# Altium schematic sheet Schematic.SchDoc(Schematic)
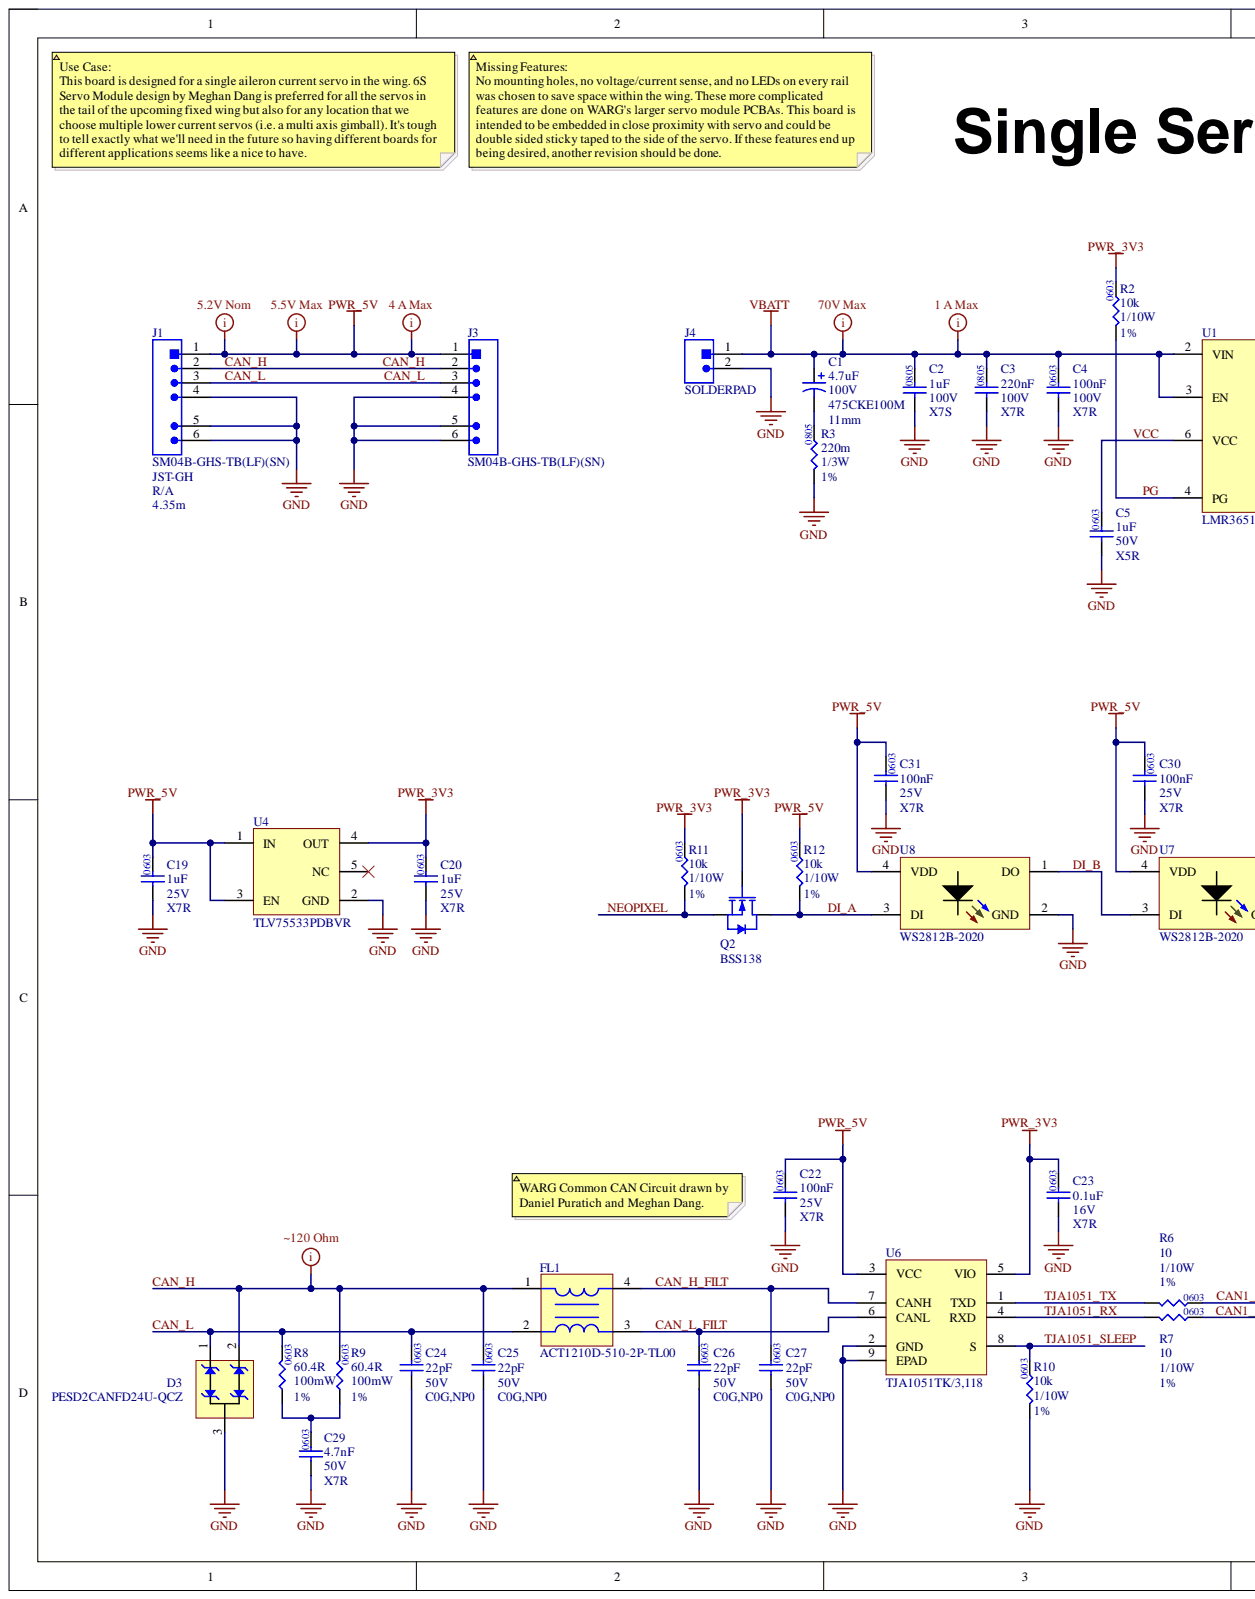
[diagram p0: Schematic.SchDoc, left]
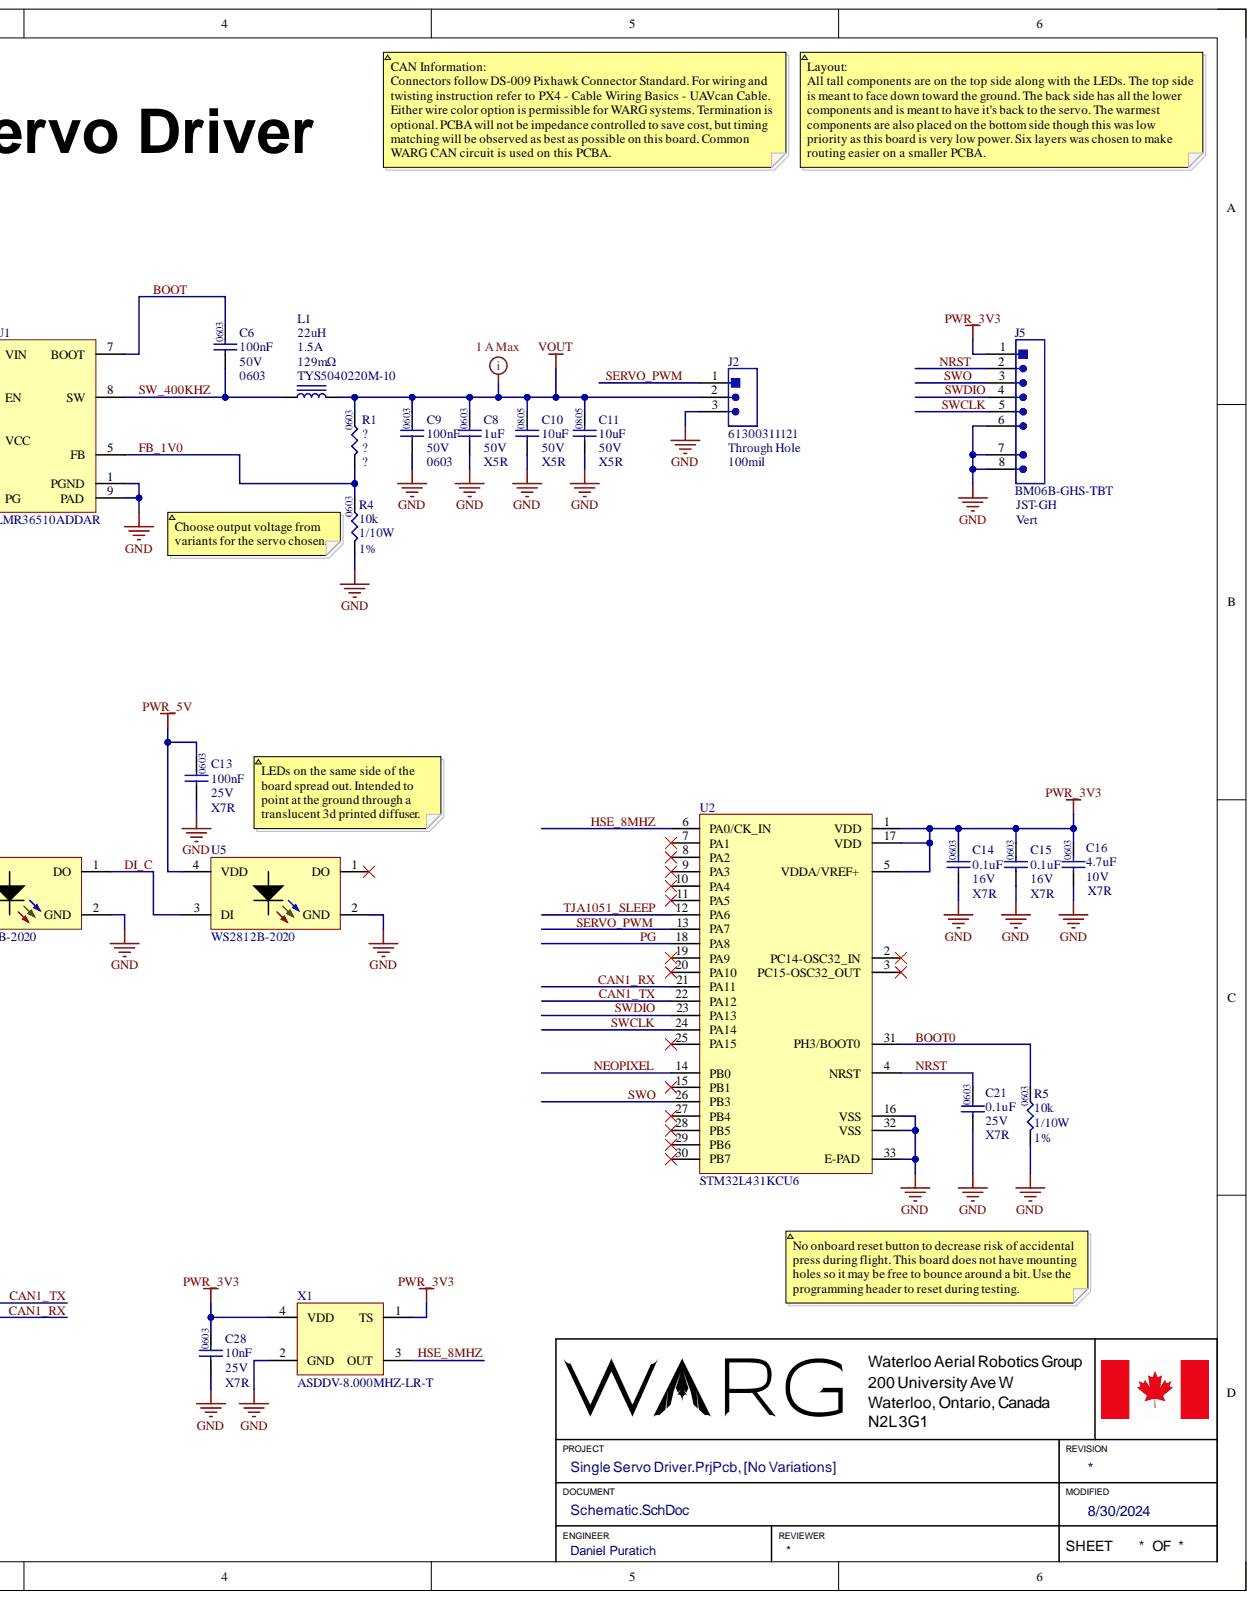
[diagram p1: Schematic.SchDoc, right]

## Parts
C1  475CKE100M  Capacitance: 4.7uF  Height: 11mm  Voltage: 100V  [475CKE100M-Footprint-1]
C2  value 1uF  Case/Package: 0805  TCR: X7S  Voltage Rating: 100V  [.CAP_0805-Footprint-1]  (hidden comment: GRM21BC72A105KE01L)
C3  Capacitance: 220nF  Package: 0805  TCR: X7R  Voltage: 100V  [.CAP_0805-Footprint-1]  (hidden comment: CL21B224KCFSNWE)
C4  value 100nF  Package: 0603  Temperature Coefficient: X7R  Voltage: 100V  [.CAP_0603-Footprint-1]  (hidden comment: CC0603KRX7R0BB104)
C5  Capacitance: 1uF  Dielectric: X5R  Package: 0603  Voltage: 50V  [.CAP_0603-Footprint-1]  (hidden comment: GRT188R61H105KE13D)
C6  Capacitance: 100nF  Case/Package: 0603  Voltage: 50V  [CL10B104KB8NNNC-Footprint-1]  (hidden comment: CL10B104KB8NNNC)
C8  Capacitance: 1uF  Dielectric: X5R  Package: 0603  Voltage: 50V  [.CAP_0603-Footprint-1]  (hidden comment: GRT188R61H105KE13D)
C9  Capacitance: 100nF  Case/Package: 0603  Voltage: 50V  [CL10B104KB8NNNC-Footprint-1]  (hidden comment: CL10B104KB8NNNC)
C10  value 10uF  Case/Package: 0805  Dielectric: X5R  Voltage Rating: 50V  [.CAP_0805-Footprint-1]  (hidden comment: GRM21BR61H106KE43L)
C11  value 10uF  Case/Package: 0805  Dielectric: X5R  Voltage Rating: 50V  [.CAP_0805-Footprint-1]  (hidden comment: GRM21BR61H106KE43L)
C13  Capacitance: 100nF  Case/Package: 0603  Dielectric: X7R  Voltage Rating: 25V  [.CAP_0603-Footprint-1]  (hidden comment: CL10B104KA8NNNC)
C14  value 0.1uF  Package: 0603  Temperature Coefficient: X7R  Voltage: 16V  [.CAP_0603-Footprint-1]  (hidden comment: GCM188R71C104KA37D)
C15  value 0.1uF  Package: 0603  Temperature Coefficient: X7R  Voltage: 16V  [.CAP_0603-Footprint-1]  (hidden comment: GCM188R71C104KA37D)
C16  value 4.7uF  Capacitance: 4.7uF  Package: 0603  Temperature Coefficient: X7R  Voltage: 10V  [.CAP_0603-Footprint-1]  (hidden comment: GRM188Z71A475KE15J)
C19  Capacitance: 1uF  Temperature Coefficient: X7R  Voltage Rating: 25V  [.CAP_0603-Footprint-1]  (hidden comment: GCM188R71E105KA64D)
C20  Capacitance: 1uF  Temperature Coefficient: X7R  Voltage Rating: 25V  [.CAP_0603-Footprint-1]  (hidden comment: GCM188R71E105KA64D)
C21  value 0.1uF  Capacitance: 0.1uF  Package: 0603  Temperature Coefficient: X7R  Voltage Rating: 25V  [.CAP_0603-Footprint-1]  (hidden comment: GCJ188R71E104KA12D)
C22  Capacitance: 100nF  Case/Package: 0603  Dielectric: X7R  Voltage Rating: 25V  [.CAP_0603-Footprint-1]  (hidden comment: CL10B104KA8NNNC)
C23  value 0.1uF  Package: 0603  Temperature Coefficient: X7R  Voltage: 16V  [.CAP_0603-Footprint-1]  (hidden comment: GCM188R71C104KA37D)
C24  value 22pF  Package: 0603  Temperature Coefficient: C0G,NP0  Voltage: 50V  [.CAP_0603-Footprint-1]  (hidden comment: CC0603JRNPO9BN220)
C25  value 22pF  Package: 0603  Temperature Coefficient: C0G,NP0  Voltage: 50V  [.CAP_0603-Footprint-1]  (hidden comment: CC0603JRNPO9BN220)
C26  value 22pF  Package: 0603  Temperature Coefficient: C0G,NP0  Voltage: 50V  [.CAP_0603-Footprint-1]  (hidden comment: CC0603JRNPO9BN220)
C27  value 22pF  Package: 0603  Temperature Coefficient: C0G,NP0  Voltage: 50V  [.CAP_0603-Footprint-1]  (hidden comment: CC0603JRNPO9BN220)
C28  Capacitance: 10nF  Package: 0603  Temperature Coefficient: X7R  Voltage Rating: 25V  [.CAP_0603-Footprint-1]  (hidden comment: CC0603KRX7R8BB103)
C29  value 4.7nF  Case/Package: 0603  Rated DC Voltage (URdc): 50V  Temperature Characteristics Code: X7R  [.CAP_0603-Footprint-1]  (hidden comment: GCM188R71H472KA37D)
C30  Capacitance: 100nF  Case/Package: 0603  Dielectric: X7R  Voltage Rating: 25V  [.CAP_0603-Footprint-1]  (hidden comment: CL10B104KA8NNNC)
C31  Capacitance: 100nF  Case/Package: 0603  Dielectric: X7R  Voltage Rating: 25V  [.CAP_0603-Footprint-1]  (hidden comment: CL10B104KA8NNNC)
D3  PESD2CANFD24U-QCZ  [PESD2CANFD24U-QCZ-Footprint-1]
FL1  ACT1210D-510-2P-TL00  [ACT1210D-510-2P-TL00-Footprint-1]
J1  SM04B-GHS-TB(LF)(SN)  Entry Type: R/A  Series: JST-GH  [CONN_SM04B-GHS-TB_JST]
J2  61300311121  Mount: Through Hole  Pitch: 100mil  [61300311121]
J3  SM04B-GHS-TB(LF)(SN)  [CONN_SM04B-GHS-TB_JST]
J4  SOLDERPAD  [SOLDERPAD_2PIN-Footprint]
J5  BM06B-GHS-TBT  Entry Type: Vert  Series: JST-GH  [BM06B-GHS-TBT-Footprint-1]
L1  TYS5040220M-10  DCR: 129mΩ  Saturation: 1.5A  [TYS5040220M-10-Footprint-1]
Q2  BSS138  [BSS138-Footprint-1]
R1  Package: 0603  [.RES_0603-Footprint-1]  (hidden comment: .RES_0603)
R1
R1
R2  value 10k  Package: 0603  Power: 1/10W  Resistance: 10k  Tolerance: 1%  [.RES_0603-Footprint-1]  (hidden comment: RC0603FR-0710KL)
R3  Package: 0805  Power: 1/3W  Resistance: 220m  Tolerance: 1%  [.RES_0805-Footprint-1]  (hidden comment: RL1220S-R22-F)
R4  value 10k  Package: 0603  Power: 1/10W  Resistance: 10k  Tolerance: 1%  [.RES_0603-Footprint-1]  (hidden comment: RC0603FR-0710KL)
R5  value 10k  Package: 0603  Power: 1/10W  Resistance: 10k  Tolerance: 1%  [.RES_0603-Footprint-1]  (hidden comment: RC0603FR-0710KL)
R6  value 10  Package: 0603  Power: 1/10W  Tolerance: 1%  [.RES_0603-Footprint-1]  (hidden comment: RC0603FR-0710RL)
R7  value 10  Package: 0603  Power: 1/10W  Tolerance: 1%  [.RES_0603-Footprint-1]  (hidden comment: RC0603FR-0710RL)
R8  value 60.4R  Power: 100mW  Tolerance: 1%  [RES0603]  (hidden comment: RC0603FR-1360R4L)
R9  value 60.4R  Power: 100mW  Tolerance: 1%  [RES0603]  (hidden comment: RC0603FR-1360R4L)
R10  value 10k  Package: 0603  Power: 1/10W  Resistance: 10k  Tolerance: 1%  [.RES_0603-Footprint-1]  (hidden comment: RC0603FR-0710KL)
R11  value 10k  Package: 0603  Power: 1/10W  Resistance: 10k  Tolerance: 1%  [.RES_0603-Footprint-1]  (hidden comment: RC0603FR-0710KL)
R12  value 10k  Package: 0603  Power: 1/10W  Resistance: 10k  Tolerance: 1%  [.RES_0603-Footprint-1]  (hidden comment: RC0603FR-0710KL)
U1  LMR36510ADDAR  [LMR36510ADDAR_8SOPWR]
U2  STM32L431KCU6  [STM32L431KCU6-Footprint-1]
U4  TLV75533PDBVR  [SOT-23-5]
U5  WS2812B-2020  [WS2812B-2020-Footprint-1]
U6  TJA1051TK/3,118  [TJA1051TK_3,118-Footprint-1]
U7  WS2812B-2020  [WS2812B-2020-Footprint-1]
U8  WS2812B-2020  [WS2812B-2020-Footprint-1]
X1  ASDDV-8.000MHZ-LR-T  [ASDDV-8.000MHZ-LR-T-Footprint-1]

## Nets
GND: C2.1 C3.1 C4.1 C5.1 C8.1 C9.1 C10.1 C11.1 C13.1 C14.1 C15.1 C16.1 C19.1 C20.1 C21.1 C22.1 C23.1 C24.1 C25.1 C26.1 C27.1 C28.1 C29.1 C30.1 C31.1 D3.3 J1.4 J1.5 J1.6 J2.3 J3.4 J3.5 J3.6 J4.2 J5.6 J5.7 J5.8 R3.1 R4.1 R5.1 R10.1 U1.1(PGND) U1.9(PAD) U2.16(VSS) U2.32(VSS) U2.33(E-PAD) U4.2(GND) U5.2(GND) U6.2(GND) U6.9(EPAD) U7.2(GND) U8.2(GND) X1.2(GND)
PWR_3V3: C14.2 C15.2 C16.2 C20.2 C23.2 C28.2 J5.1 Q2.G R2.2 R11.2 U2.1(VDD) U2.5(VDDA/VREF+) U2.17(VDD) U4.4(OUT) U6.5(VIO) X1.1(TS) X1.4(VDD)
PWR_5V: C13.2 C19.2 C22.2 C30.2 C31.2 J1.1 J3.1 R12.2 U4.1(IN) U4.3(EN) U5.4(VDD) U6.3(VCC) U7.4(VDD) U8.4(VDD)
VBATT: C1.1 C2.2 C3.2 C4.2 J4.1 U1.2(VIN) U1.3(EN)
VOUT: C8.2 C9.2 C10.2 C11.2 J2.2 L1.2 R1.2
BOOT: C6.2 U1.7(BOOT)
BOOT0: R5.2 U2.31(PH3/BOOT0)
CAN1_RX: R7.2 U2.21(PA11)
CAN1_TX: R6.2 U2.22(PA12)
CAN_H: C25.2 D3.2 FL1.1 J1.2 J3.2 R9.2
CAN_H_FILT: C27.2 FL1.4 U6.7(CANH)
CAN_L: C24.2 D3.1 FL1.2 J1.3 J3.3 R8.2
CAN_L_FILT: C26.2 FL1.3 U6.6(CANL)
DI_A: Q2.D R12.1 U8.3(DI)
DI_B: U7.3(DI) U8.1(DO)
DI_C: U5.3(DI) U7.1(DO)
FB_1V0: R1.1 R4.2 U1.5(FB)
HSE_8MHZ: U2.6(PA0/CK_IN) X1.3(OUT)
NEOPIXEL: Q2.S R11.1 U2.14(PB0)
NRST: C21.2 J5.2 U2.4(NRST)
PG: R2.1 U1.4(PG) U2.18(PA8)
SERVO_PWM: J2.1 U2.13(PA7)
SW_400KHZ: C6.1 L1.1 U1.8(SW)
SWCLK: J5.5 U2.24(PA14)
SWDIO: J5.4 U2.23(PA13)
SWO: J5.3 U2.26(PB3)
TJA1051_RX: R7.1 U6.4(RXD)
TJA1051_SLEEP: R10.2 U2.12(PA6) U6.8(S)
TJA1051_TX: R6.1 U6.1(TXD)
VCC: C5.2 U1.6(VCC)
NetC1_2: C1.2 R3.2
NetC29_2: C29.2 R8.1 R9.1

Not connected: U2 2(PC14-OSC32_IN) 3(PC15-OSC32_OUT) 7(PA1) 8(PA2) 9(PA3) 10(PA4) 11(PA5) 15(PB1) 19(PA9) 20(PA10) 25(PA15) 27(PB4) 28(PB5) 29(PB6) 30(PB7); U4 5(NC); U5 1(DO)

## Library data of the parts
C1 = CMP-00301-1: CAP ALUM 4.7UF 20% 100V RADIAL; Component Kind: Standard; Library Name: WARG
C2 = CMP-00124-2: CAP CER 1UF 100V X7S 0805; Tolerance: 10%; Component Kind: Standard; Library Name: WARG
C3 = CMP-00299-1: CAP CER 0.22UF 100V X7R 0805; Component Kind: Standard; Library Name: WARG
C4 = CMP-00249-4: CAP CER 0.1UF 100V X7R 0603; Component Kind: Standard; Library Name: WARG
C5 C8 = CMP-00096-4: CAP CER 1UF 50V X5R 0603; Component Kind: Standard; Library Name: WARG
C6 C9 = CMP-00018-6: CAP CER 0.1uF 50V X7R 0603; Tolerance: 10%; Component Kind: Standard; Depth: 0.8mm; Dielectric: X7R; Height: 0.8mm; Lead Free: Lead Free; Length: 1.6mm; Max Operating Temperature: 125°C; Min Operating Temperature: -55°C; Mount: Surface Mount; Voltage Rating (DC): 50V; Library Name: WARG
C10 C11 = CMP-001-00027-2: CAP CER 10uF 50V X5R 0805; Component Kind: Standard; Library Name: WARG
C13 C22 C30 C31 = CMP-00057-2: CAP CER 0.1UF 25V X7R 0603; Tolerance: 10%; Component Kind: Standard; Library Name: WARG
C14 C15 C23 = CMP-001-00003-9: CAP CER 100nF 16V X7R 0603; Capacitance: 100nF; Component Kind: Standard; Library Name: WARG
C16 = CMP-001-00045-2: CAP CER 4.7UF 10V X7R 0603; Component Kind: Standard; Library Name: WARG
C19 C20 = CMP-00240-2: CAP CER 1UF 25V X7R 0603; Tolerance: 10%; Component Kind: Standard; Mounting Technology: Surface Mount; Library Name: WARG
C21 = CMP-001-00036-3: CAP CER 0.1UF 25V X7R 0603; Component Kind: Standard; Library Name: WARG
C24-C27 = CMP-00246-3: CAP CER 22PF 50V C0G/NPO 0603; Tolerance: 5%; Component Kind: Standard; Library Name: WARG
C28 = CMP-00217-1: CAP CER 10nF 25V X7R 0603; Component Kind: Standard; Library Name: WARG
C29 = CMP-001-00080-1: CAP CER 4700PF 50V X7R 0603; Capacitor Type: Ceramic; Multilayer: Yes; Reference Standard: AEC-Q200; Tolerance  (fill in as +-): 10%; Component Kind: Standard; Dielectric Material: Ceramic; Height: 0.9mm; JESD-609 Code: e3; Length: 1.6mm; Max Operating Temperature: 125°C; Min Operating Temperature: -55°C; Mounting Technology: Surface Mount; Package Shape: Rectangular; Package Style: SMTMeter; Packaging: Tape and Reel; Pins: 2; Reach SVHC Compliant: Yes; RoHS Compliant: Yes; Terminal Finish: Nickel; Termination: Wraparound; Tolerance (fill in as +-): 10%; Width: 0.8mm; Library Name: WARG
D3 = CMP-00239-5: TVS DIODE 24VWM 43VC DFN1412D-3; Component Kind: Standard; Library Name: WARG
FL1 = CMP-00243-2: CMC 50UH 115MA 2LN 2.3KOHM SMD; Component Kind: Standard; Library Name: WARG
J1 = CMP-068-000000-5: Conn Shrouded Header HDR 4 POS 1.25mm Solder RA SMD Reel; Component Kind: Standard; Height: 4.35m; Pins: 4; Library Name: WARG
J2 = CMP-00111-3: CONN HDR 3 POS 2.54; Connector Type: Male Pin; Positions: 3; Component Kind: Standard; Library Name: WARG
J3 = CMP-068-000000-5: Conn Shrouded Header HDR 4 POS 1.25mm Solder RA SMD Reel; Entry Type: R/A; Component Kind: Standard; Pins: 4; Series: JST-GH; Library Name: WARG
J4 = CMP-00296-1: Solder Pad 2 Pins, 2.54mm pitch, 1.27mm pad size; Component Kind: Standard; Library Name: WARG
J5 = CMP-002-00014-8: Connector Header Surface Mount 6 position 0.049" (1.25mm); Component Kind: Standard; Pins: 6; Library Name: WARG
L1 = CMP-00298-2: FIXED IND 22UH 1.5A 129 MOHM SMD; Component Kind: Standard; Inductance: 22uH; Library Name: WARG
Q2 = CMP-00295-2: MOSFET N-CH 50V 220MA SOT23-3; Component Kind: Standard; Library Name: WARG
R1 = CMP-009-00000-5: GENERIC 0603 RES; Component Kind: Standard; Power: ?; Resistance: ?; Tolerance: ?; Value: ?; Library Name: WARG
R2 R4 R5 R10-R12 = CMP-009-00006-5: RES 10K OHM 1% 1/10W 0603; Component Kind: Standard; Library Name: WARG
R3 = CMP-00300-1: RES 0.22 OHM 1% 1/3W 0805; Component Kind: Standard; Library Name: WARG
R6 R7 = CMP-009-00084-2: RES 10 OHM 1% 1/10W 0603; Component Kind: Standard; Resistance: 10; Library Name: WARG
R8 R9 = CMP-00245-1: RES 60.4 OHM 1% 1/10W 0603; Component Kind: Standard; Library Name: WARG
U1 = CMP-00297-1: IC REG BUCK ADJ 1A 8SOPWR; Component Kind: Standard; Library Name: WARG
U2 = CMP-00224-5: IC MCU 32BIT 256KB FLSH 32UFQFPN; Component Kind: Standard; Library Name: WARG
U4 = CMP-00225-4: IC REG LINEAR 3.3V 500MA SOT23-5; Component Kind: Standard; Library Name: WARG
U5 U7 U8 = CMP-00294-3: LED Neopixel 2mm; Component Kind: Standard; Library Name: WARG
U6 = CMP-00219-3: IC TRANSCEIVER HALF 1/1 8HVSON; Component Kind: Standard; Library Name: WARG
X1 = CMP-00242-3: OSC XO 8.000MHZ CMOS SMD; Frequence Stability: 25ppm; Frequency: 8MHz; Component Kind: Standard; Library Name: WARG
